# Altium schematic sheet Sheet1.SchDoc
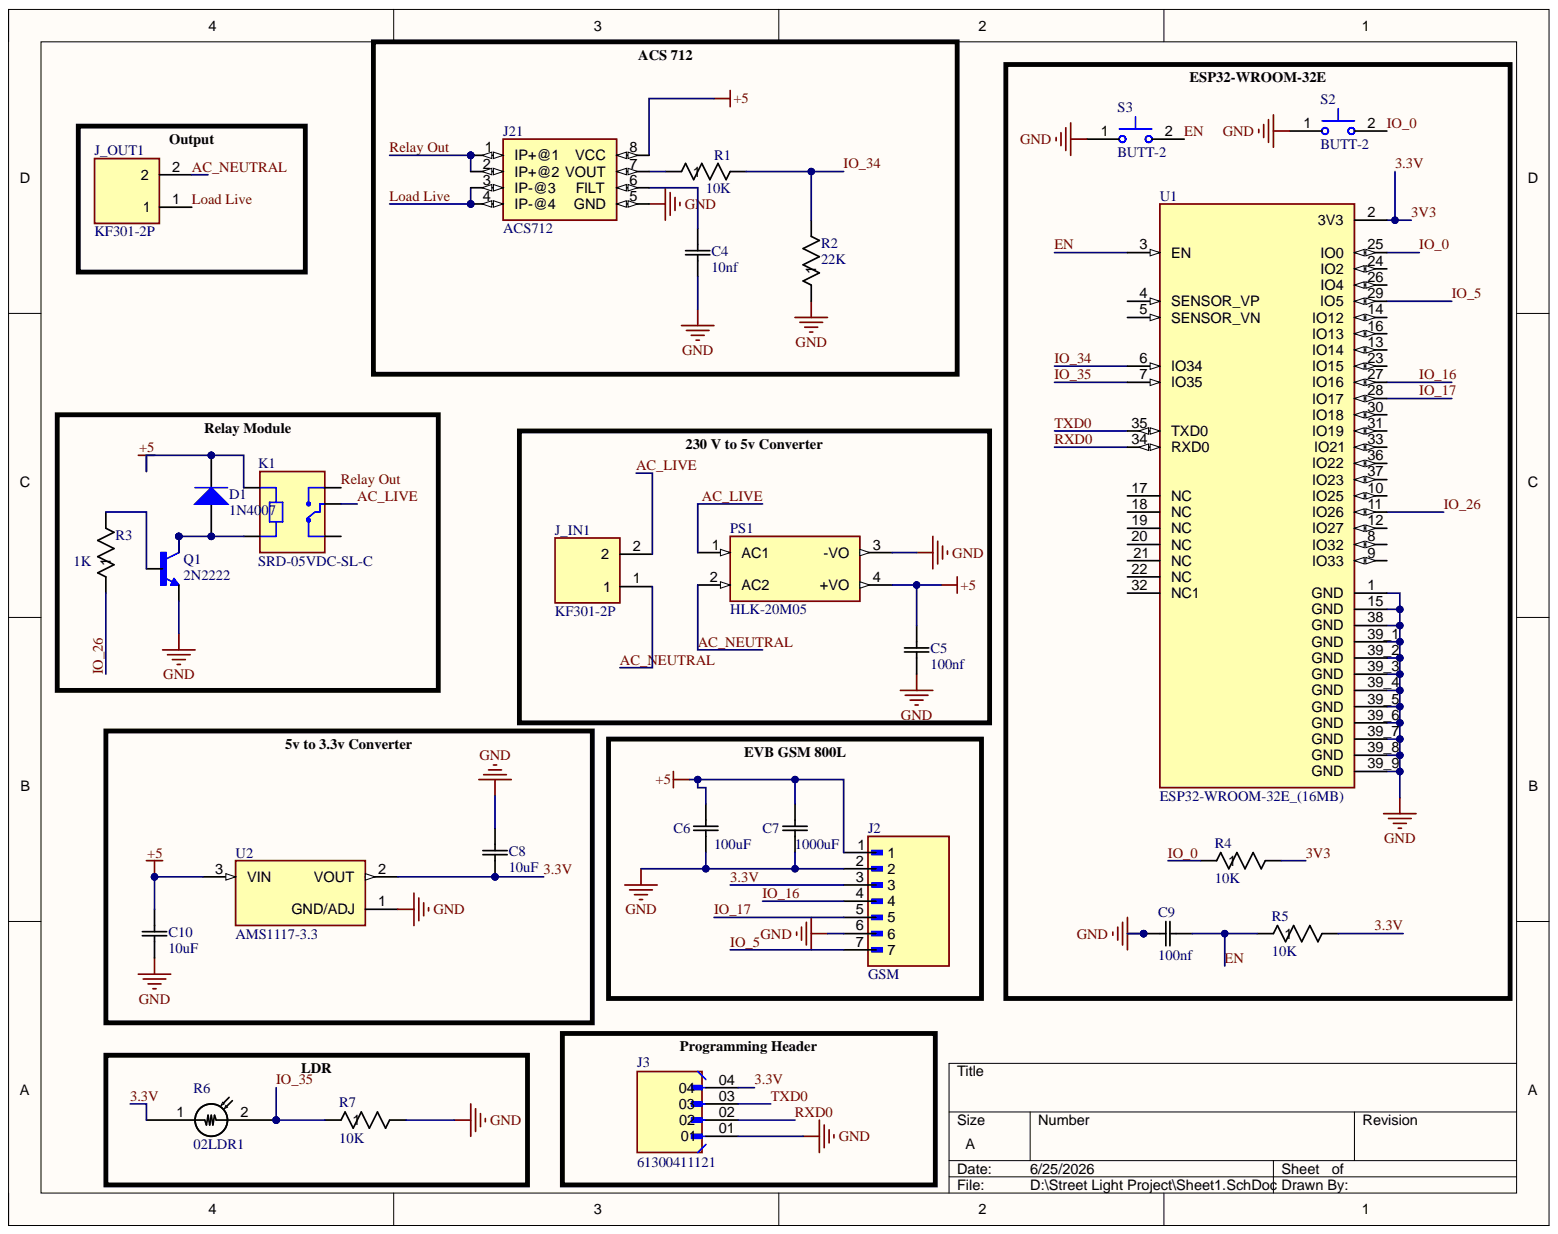
[diagram p0: Sheet1.SchDoc]

## Parts
C4  10nf  [CAP_Z5VF63L6R_VIS]
C5  100nf  [CAP_Z5VF63L6R_VIS]
C6  100uF  [CAP_YX_6P3X11_RUB]
C7  1000uF  [CAP_PKPZ_10X16_LOW_RUB]
C8  10uF  [CAP_YX_6P3X11_RUB]
C9  100nf  [CAP_Z5VF63L6R_VIS]
C10  10uF  [CAP_YX_6P3X11_RUB]
D1  1N4007  [FAIR-DO-41-2]
J2  GSM  [JST_S7B-XH-A(LF)(SN)]
J3  61300411121  [WURTH_61300411121]
J21  ACS712  [SO08]
J_IN1  KF301-2P  [HANDSON_KF301-2P]
J_OUT1  KF301-2P  [HANDSON_KF301-2P]
K1  SRD-05VDC-SL-C  [RELAY_SRD-05VDC-SL-C]
PS1  HLK-20M05  [CONV_HLK-10M05]
Q1  2N2222  [TO127P580H530-3]
R1  10K  [YAG_CFR25_YAG]
R2  22K  [YAG_CFR25_YAG]
R3  1K  [YAG_CFR25_YAG]
R4  10K  [YAG_CFR25_YAG]
R5  10K  [YAG_CFR25_YAG]
R6  02LDR1  [LDR1_NTE]
R7  10K  [YAG_CFR25_YAG]
S2  BUTT-2  [SW_BUTT-2]
S3  BUTT-2  [SW_BUTT-2]
U1  ESP32-WROOM-32E_(16MB)  [XCVR_ESP32-WROOM-32E_(16MB)]
U2  AMS1117-3.3  [SOT229P700X180-4N]

## Nets
+5: C5.2 C6.2 C7.2 C10.2 D1.1 J2.1 J21.8(VCC) K1.A1 PS1.4(+VO) U2.3
GND: C4.1 C5.1 C6.1 C7.1 C8.2 C9.1 C10.1 J2.2 J2.6 J3.01 J21.5(GND) PS1.3(-VO) Q1.1 R2.1 R7.2 S2.1 S3.1 U1.1(GND) U1.15(GND) U1.38(GND) U1.39_1(GND) U1.39_2(GND) U1.39_3(GND) U1.39_4(GND) U1.39_5(GND) U1.39_6(GND) U1.39_7(GND) U1.39_8(GND) U1.39_9(GND) U2.1
3.3V: C8.1 J2.3 J3.04 R4.2 R5.2 R6.1 U1.2(3V3) U2.2
AC_LIVE: J_IN1.2 K1.COM PS1.1(AC1)
AC_NEUTRAL: J_IN1.1 J_OUT1.2 PS1.2(AC2)
EN: C9.2 R5.1 S3.2 U1.3(EN)
IO_0: R4.1 S2.2 U1.25(IO0)
IO_5: J2.7 U1.29(IO5)
IO_16: J2.4 U1.27(IO16)
IO_17: J2.5 U1.28(IO17)
IO_26: R3.1 U1.11(IO26)
IO_34: R1.2 R2.2 U1.6(IO34)
IO_35: R6.2 R7.1 U1.7(IO35)
Load Live: J21.3(IP-@3) J21.4(IP-@4) J_OUT1.1
Relay Out: J21.1(IP+@1) J21.2(IP+@2) K1.NO
RXD0: J3.02 U1.34(RXD0)
TXD0: J3.03 U1.35(TXD0)
NetC4_2: C4.2 J21.6(FILT)
NetD1_2: D1.2 K1.A2 Q1.3
NetJ21_7: J21.7(VOUT) R1.1
NetQ1_2: Q1.2 R3.2

Not connected: K1 NC; U1 4(SENSOR_VP) 5(SENSOR_VN) 8(IO32) 9(IO33) 10(IO25) 12(IO27) 13(IO14) 14(IO12) 16(IO13) 17(NC) 18(NC) 19(NC) 20(NC) 21(NC) 22(NC) 23(IO15) 24(IO2) 26(IO4) 30(IO18) 31(IO19) 32(NC1) 33(IO21) 36(IO22) 37(IO23)

## Library data of the parts
C4 = D103Z25Z5VF63L6R: 10nF 50V; Manufacturer_Name: Vishay; Component Kind: Standard; Library Name: D103Z25Z5VF63L6R.IntLib; Manufacturer_Part_Number: D103Z25Z5VF63L6R; Copyright: Copyright (C) 2025 Ultra Librarian. All rights reserved.
C5 C9 = D103Z25Z5VF63L6R: 100nF 50V; Manufacturer_Name: Vishay; Component Kind: Standard; Library Name: D103Z25Z5VF63L6R.IntLib; Manufacturer_Part_Number: D103Z25Z5VF63L6R; Copyright: Copyright (C) 2025 Ultra Librarian. All rights reserved.
C6 C8 C10 = 25YXJ220M6.3X11: Description; Manufacturer_Name: Rubycon; Component Kind: Standard; Library Name: 25YXJ220M6P3X11.IntLib; Manufacturer_Part_Number: 25YXJ220M6.3X11; Copyright: Copyright (C) 2025 Ultra Librarian. All rights reserved.
C7 = 25PX1000MEFCT810X16: Description; Manufacturer_Name: Rubycon; Component Kind: Standard; Library Name: 25PX1000MEFCT810X16.IntLib; Manufacturer_Part_Number: 25PX1000MEFCT810X16; Copyright: Copyright (C) 2025 Ultra Librarian. All rights reserved.
D1 = CMP-004-00007-2: General Purpose Rectifier, 1.1 V, 1.0 A, -55 to 175 degC, 2-Pin DO-41, RoHS, Tape and Reel; Manufacturer: Fairchild; Elements: 1; Forward Current: 1A; Forward Voltage: 1.1V; Max Surge Current: 30A; Package Version: Rev. 2, 09/2008; Peak Reverse Current: 5uA; Case/Package: DO-41-2; Component Kind: Standard; Datasheet URL: https://www.fairchildsemi.com/datasheets/1N/1N4007.pdf; Datasheet Version: Rev. 1.1.0, 11/2014; Manufacturer URL: https://www.fairchildsemi.com/; Max Operating Temperature: 175°C; Min Operating Temperature: -55°C; Mounting Technology: TH; Package Description: 2-Pin Axial Lead, Dia 2.375 mm, Body Length 4.63 mm; Package Quantity: 5000; Package Reference: DO-41-2; Package URL: http://www.fairchildsemi.com/dwg/DO/DO41A.pdf; Packaging: TapeandReel; Pins: 2; RoHS Compliant: True; Library Name: UOM
J2 = S7B-XH-A(LF)(SN): Connector Header Through Hole, Right Angle 7 position 0.098 (2.50mm); MF: JST Sales; Check_prices: https://www.snapeda.com/parts/S7B-XH-A(LF)(SN)/JST/view-part/?ref=eda; Component Kind: Standard; Library Name: S7B-XH-A_LF__SN_.IntLib; MP: S7B-XH-A(LF)(SN); Package: None; SnapEDA_Link: https://www.snapeda.com/parts/S7B-XH-A(LF)(SN)/JST/view-part/?ref=snap
J3 = 61300411121: Connector Header Through Hole 4 position 0.100 (2.54mm); MF: WÃ¼rth Elektronik; Check_prices: https://www.snapeda.com/parts/61300411121/W%25C3%25BCrth+Elektronik+Midcom/view-part/?ref=eda; Component Kind: Standard; Library Name: 61300411121.IntLib; MP: 61300411121; Package: None; SnapEDA_Link: https://www.snapeda.com/parts/61300411121/W%25C3%25BCrth+Elektronik+Midcom/view-part/?ref=snap
J21 = ACS712: Current Sensor 20A 1 Channel Hall Effect, Open Loop Bidirectional 8-SOIC (0.154, 3.90mm Width); MF: Allegro MicroSystems; Check_prices: https://www.snapeda.com/parts/ACS712/Allegro/view-part/?ref=eda; Component Kind: Standard; Library Name: ACS712.IntLib; MP: ACS712; Package: SOIC-8 Allegro MicroSystems LLC; SnapEDA_Link: https://www.snapeda.com/parts/ACS712/Allegro/view-part/?ref=snap
J_IN1 J_OUT1 = KF301-2P: 2 Pin Terminal Block 5mm Pitch; MF: Handson Technology; Check_prices: https://www.snapeda.com/parts/KF301-2P/Handson+Technology/view-part/?ref=eda; Component Kind: Standard; Library Name: KF301-2P.IntLib; MP: KF301-2P; Package: None; SnapEDA_Link: https://www.snapeda.com/parts/KF301-2P/Handson+Technology/view-part/?ref=snap
K1 = SRD-05VDC-SL-C: 5V Trigger Relay Module For Arduino And Raspberry Pi 5V Trigger Relay Module For Arduino And Raspberry Pi; MF: Songle Relay; Availability: Not in stock; Check_prices: https://www.snapeda.com/parts/SRD-05VDC-SL-C/Songle+Relay/view-part/?ref=eda; Component Kind: Standard; Library Name: SRD-05VDC-SL-C.IntLib; MP: SRD-05VDC-SL-C; Package: NON STANDARD-5 Songle Relay; Price: None; SnapEDA_Link: https://www.snapeda.com/parts/SRD-05VDC-SL-C/Songle+Relay/view-part/?ref=snap
PS1 = HLK-10M05: 20W Ultra-Compact Power Module; MF: Hi-link; Check_prices: https://www.snapeda.com/parts/HLK-10M05/Hi-link/view-part/?ref=eda; Component Kind: Standard; Library Name: HLK-10M05.IntLib; MP: HLK-10M05; Package: Package; SnapEDA_Link: https://www.snapeda.com/parts/HLK-10M05/Hi-link/view-part/?ref=snap
Q1 = 2N2222: Bipolar (BJT) Transistor NPN 30 V 600 mA 250MHz 500 mW Through Hole TO-18; MF: STMicroelectronics; Check_prices: https://www.snapeda.com/parts/2N2222/STMicroelectronics/view-part/?ref=eda; Component Kind: Standard; Library Name: 2N2222.IntLib; MP: 2N2222; Package: TO-18 Texas Instruments; SnapEDA_Link: https://www.snapeda.com/parts/2N2222/STMicroelectronics/view-part/?ref=snap
R1-R5 R7 = CFR-25JB-52-1K: Description; Manufacturer_Name: Yageo; Component Kind: Standard; Library Name: CFR-25JB-52-1K.IntLib; Manufacturer_Part_Number: CFR-25JB-52-1K; Copyright: Copyright (C) 2026 Ultra Librarian. All rights reserved.
R6 = 02LDR1: Description; Manufacturer_Name: NTE Electronics; Component Kind: Standard; Library Name: 02LDR1.IntLib; Manufacturer_Part_Number: 02LDR1; RefDes: RefDes; Type: Type; Copyright: Copyright (C) 2026 Ultra Librarian. All rights reserved.
S2 S3 = BUTT-2: Tactile Switches MINI PUSH BUTTON SWITCH 2-PIN QTY. 4; MF: Gravitech; Check_prices: https://www.snapeda.com/parts/BUTT-2/Gravitech/view-part/?ref=eda; Component Kind: Standard; Library Name: BUTT-2.IntLib; MP: BUTT-2; Package: None; SnapEDA_Link: https://www.snapeda.com/parts/BUTT-2/Gravitech/view-part/?ref=snap
U1 = ESP32-WROOM-32E_(16MB): Bluetooth, WiFi 802.11b/g/n, Bluetooth v4.2 +EDR, Class 1, 2 and 3 Transceiver Module 2.4GHz ~ 2.5GHz Integrated, Trace Surface Mount; MF: Espressif Systems; MP: ESP32-WROOM-32E (16MB); Availability: Not in stock; Check_prices: https://www.snapeda.com/parts/ESP32-WROOM-32E%20(16MB)/Espressif+Systems/view-part/?ref=eda; Component Kind: Standard; Library Name: ESP32-WROOM-32E__16MB_.IntLib; Package: None; Price: None; SnapEDA_Link: https://www.snapeda.com/parts/ESP32-WROOM-32E%20(16MB)/Espressif+Systems/view-part/?ref=snap
U2 = AMS1117-3.3: Sot223/Pkg 1-Amp 3.3-Volt Low Drop Out Voltage Regulatator; MF: Advanced Monolithic Systems; Check_prices: https://www.snapeda.com/parts/AMS1117-3.3/Advanced+Monolithic+Systems/view-part/?ref=eda; Component Kind: Standard; Library Name: AMS1117-3.3.IntLib; MP: AMS1117-3.3; Package: SOT-223 Seeed Technology; SnapEDA_Link: https://www.snapeda.com/parts/AMS1117-3.3/Advanced+Monolithic+Systems/view-part/?ref=snap
